# Altium schematic sheet air qulity monitor.SchDoc("air qulity monitor")
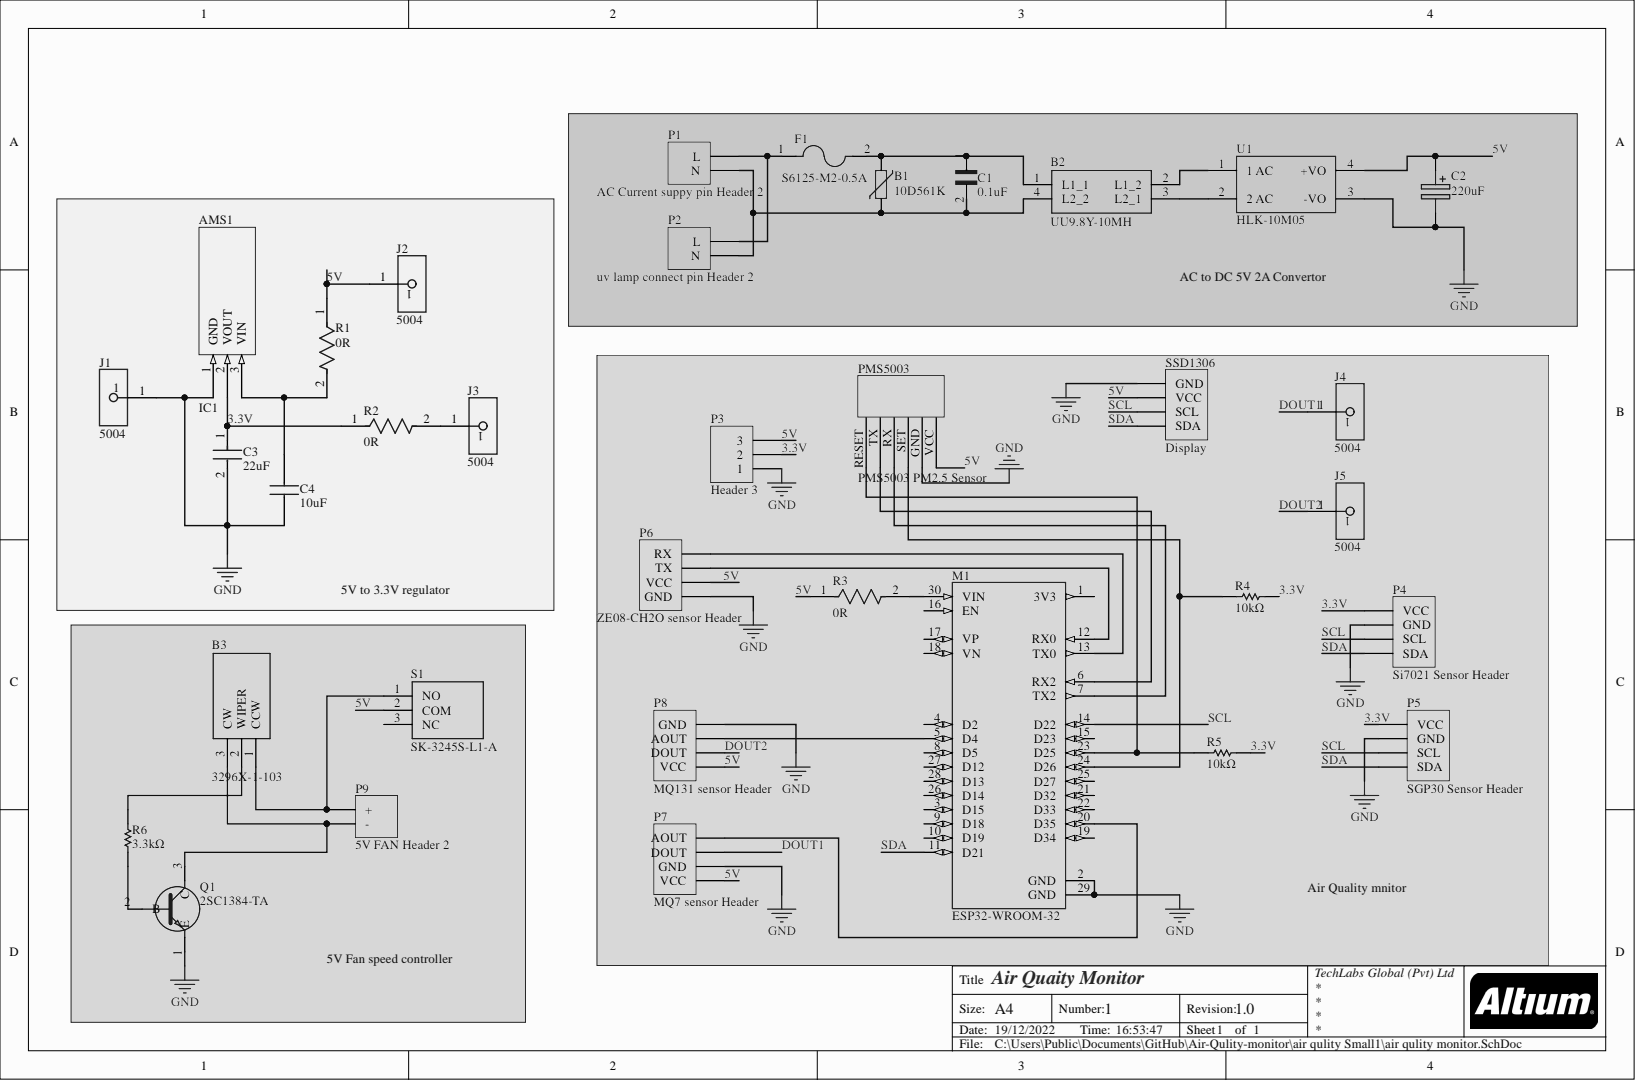
[diagram p0: air qulity monitor.SchDoc]

## Parts
AMS1  IC1  [SOT89-150P350X150-3N]
B1  10D561K  [10D561K]
B2  UU9.8Y-10MH  [UU98Y10MH]
B3  3296X-1-103  [3296X1223LF]
C1  0.1uF  [MPX-104K275VAC-C-D2]
C2  220uF  [CAPAE830X1050N]
C3  22uF  [FP-GRM219-0_2-0_15-IPC_A]
C4  10uF  [CAPC1005X70N]
F1  S6125-M2-0.5A  [CAPC6125X25N]
J1  5004  [FP-5004-MFG]
J2  5004  [FP-5004-MFG]
J3  5004  [FP-5004-MFG]
J4  5004  [FP-5004-MFG]
J5  5004  [FP-5004-MFG]
M1  ESP32-WROOM-32  [ESP32-DEVKIT-V1]
P1  AC Current suppy pin Header 2  [HDR1X2]
P2  uv lamp connect pin Header 2  [HDR1X2]
P3  Header 3  [HDR1X3]
P4  Si7021 Sensor Header  [HDR1X4]
P5  SGP30 Sensor Header  [HDR1X4]
P6  ZE08-CH2O sensor Header  Mfr.Part #: ZE08-CH2O  [HDR1X4]
P7  MQ7 sensor Header  [HDR1X4]
P8  MQ131 sensor Header  [HDR1X4]
P9  5V FAN Header 2  [HDR1X2]
PMS5003_PM2.5_Sensor  PMS5003 PM2.5 Sensor  Mfr.Part #: PMS5003  [PMS5003 PM2.5 Sensor]
Q1  2SC1384-TA  [2SC1384-TA]
R1  0R  [FP-RC0402-0_4-IPC_A]
R2  0R  [FP-RC0402-0_4-IPC_A]
R3  0R  [FP-RC0402-0_4-IPC_A]
R4  10kΩ  [RESC1005X40X25LL05T10]
R5  10kΩ  [RESC1005X40X25LL05T10]
R6  3.3kΩ  [RESC1608X55X25ML10T15]
S1  SK-3245S-L1-A  [SK3245SL1A]
SSD1306  Display  [HDR1X4]
U1  HLK-10M05  [FP-HLK-10M05-MFG]

## Nets
GND: AMS1.1 C2.2 C3.2 C4.1 J1.1 M1.2(GND) M1.29(GND) P3.1 P4.2(GND) P5.2(GND) P6.1(GND) P7.2(GND) P8.4(GND) PMS5003_PM2.5_Sensor.GND Q1.1 SSD1306.1(GND) U1.3(-VO)
3.3V: AMS1.2 C3.1 P3.2 P4.1(VCC) P5.1(VCC) R2.1 R4.2 R5.2
5V: C2.1 J2.1 P3.3 P6.2(VCC) P7.1(VCC) P8.1(VCC) PMS5003_PM2.5_Sensor.VCC R1.1 R3.1 S1.2 SSD1306.2(VCC) U1.4(+VO)
DOUT1: J4.1 P7.3
DOUT2: J5.1 P8.2
SCL: M1.14(D22) P4.3(SCL) P5.3(SCL) SSD1306.3(SCL)
SDA: M1.11(D21) P4.4(SDA) P5.4(SDA) SSD1306.4(SDA)
NetAMS1_3: AMS1.3 C4.2 R1.2
NetB1_1: B1.1 B2.1(L1_1) C1.1 F1.2
NetB1_2: B1.2 B2.4(L2_2) C1.2 P1.1 P2.1
NetB2_2: B2.2(L1_2) U1.1(1_AC)
NetB2_3: B2.3(L2_1) U1.2(2_AC)
NetB3_1: B3.1 P9.1 S1.1
NetB3_2: B3.2 R6.2
NetB3_3: B3.3 P9.2 Q1.3
NetF1_1: F1.1 P1.2 P2.2
NetJ3_1: J3.1 R2.2
NetM1_5: M1.5(D4) P8.3
NetM1_6: M1.6(RX2) PMS5003_PM2.5_Sensor.TX
NetM1_7: M1.7(TX2) PMS5003_PM2.5_Sensor.RX
NetM1_12: M1.12(RX0) P6.3(TX)
NetM1_13: M1.13(TX0) P6.4(RX)
NetM1_20: M1.20(D35) P7.4
NetM1_23: M1.23(D25) PMS5003_PM2.5_Sensor.RESET R5.1
NetM1_24: M1.24(D26) PMS5003_PM2.5_Sensor.SET R4.1
NetM1_30: M1.30(VIN) R3.2
NetQ1_2: Q1.2 R6.1
N00044:

Not connected: M1 1(3V3) 3(D15) 4(D2) 8(D5) 9(D18) 10(D19) 15(D23) 16(EN) 17(VP) 18(VN) 19(D34) 21(D32) 22(D33) 25(D27) 26(D14) 27(D12) 28(D13); S1 3

## Library data of the parts
AMS1 = IC?: 75dB@(120Hz) 1A 1.25V@(1A) ±125℃@(Tj) Fixed 3.3V~3.3V Positive 1 20V SOT-89 Linear Voltage Regulators (LDO) ROHS; Manufacturer: YONGYUTAI; Mfr. Part #: AMS1117-3.3; Component Kind: Standard; Datasheet: https://datasheet.lcsc.com/lcsc/2110121330_YONGYUTAI-AMS1117-3-3_C2904742.pdf; LCSC Part #: C2904742; Library Name: AMS1117-3.3.SchLib; Package: SOT-89
B1 = 10D561K: 920V 42.3J 180pF@1kHz 460V 350V 504V~616V 2.5kA Plugin Varistors ROHS; Manufacturer: Hongzhi Elec; Component Kind: Standard; Datasheet: https://datasheet.lcsc.com/lcsc/1811141751_Hongzhi-Elec-10D561K_C113236.pdf; LCSC Part #: C113236; Library Name: 10D561K.SchLib; Mfr. Part #: 10D561K; Package: Plugin
B2 = UU9.8Y-10MH: 10mH@1kHz 1.2Ω 2 500mA 50V Plugin Common Mode Filters ROHS; Manufacturer: FH (Guangdong Fenghua Advanced Tech); Component Kind: Standard; Datasheet: https://datasheet.lcsc.com/lcsc/1810311213_FH--Guangdong-Fenghua-Advanced-Tech-UU9-8Y-10mH_C75192.pdf; LCSC Part#: C75192; Library Name: UU9.8Y-10MH.SchLib; Mfr.Part #: UU9.8Y-10MH; Package: Plugin
B3 = 3296X-1-103: ±10% 10kΩ Plugin Variable Resistors/Potentiometers ROHS; Manufacturer: BOCHEN(Chengdu Guosheng Tech); Component Kind: Standard; Datasheet: https://datasheet.lcsc.com/lcsc/1811011704_BOCHEN-Chengdu-Guosheng-Tech-3296X-1-103_C118955.pdf; LCSC Part #: C118955; Library Name: 3296X-1-103.SchLib; Mfr. Part #: 3296X-1-103; Package: Plugin
C1 = MPX-104K275VAC-C-D2: 0.1uF ±10% -40℃~+110℃ X2 275VAC Radial Leaded,P=15mm Suppression Capacitors ROHS; Manufacturer: CORETONG; Component Kind: Standard; Datasheet: https://datasheet.lcsc.com/lcsc/2207051802_CORETONG-MPX-104K275VAC-C-D2_C3249976.pdf; LCSC Part #: C3249976; Library Name: MPX-104K275VAC-C-D2.SchLib; Mfr. Part #: MPX-104K275VAC-C-D2; Package: Radial Leaded,P=15mm; Value: 0.1uF
C2 = UUR1C221MNL1GS: -40℃~+85℃ 2000hrs@85℃ 220uF 10mm 16V 8mm 215mA@120Hz ±20% SMD,D8xL10mm Aluminum Electrolytic Capacitors - SMD ROHS; Manufacturer: Nichicon; Component Kind: Standard; Datasheet: https://datasheet.lcsc.com/lcsc/2002121532_Nichicon-UUR1C221MNL1GS_C445237.pdf; LCSC Part#: C445237; Library Name: UUR1C221MNL1GS.SchLib; Mfr.Part #: UUR1C221MNL1GS; Package: SMD,D8xL10mm; Value: 220uF
C3 = CMP-06035-056251-1: Chip Multilayer Ceramic Capacitors for General Purpose, 0805, 22uF, X5R, 15%, 20%, 16V; Manufacturer: Murata Electronics; Mfr. Part #: GRM219R61C226ME15L; Component Kind: Standard; Datasheet: https://datasheet.lcsc.com/lcsc/1811141821_Murata-Electronics-GRM219R61C226ME15L_C162295.pdf; LCSC Part #: C162295; Library Name: Altium Content Vault; Package: 0805; value: 22uF
C4 = CL05A106MQ5NUNC: 6.3V 10uF X5R ±20% 0402 Multilayer Ceramic Capacitors MLCC - SMD/SMT ROHS; Manufacturer: Samsung Electro-Mechanics; Component Kind: Standard; Datasheet Link: https://datasheet.datasheetarchive.com/originals/distributors/Datasheets-DGA10/2406160.pdf; LCSC Part #: C15525; Library Name: CL05A106MQ5NUNC.SchLib; Mfr. Part #: CL05A106MQ5NUNC; Package: 0402; value: 10uF
F1 = S6125-M2-0.5A: SMD Fuses ROHS; Manufacturer: SART(Nanjing Sart Tech); Component Kind: Standard; Datasheet: https://datasheet.lcsc.com/lcsc/2201242130_SART-Nanjing-Sart-Tech-S6125-M2-0-5A_C845172.pdf; LCSC Part #: C845172; Library Name: S6125-M2-0.5A.SchLib; Mfr. Part #: S6125-M2-0.5A; Package: SMD
J1-J5 = CMP-2000-05575-2: PC TEST POINT MINIATURE YELLOW; Manufacturer: Keystone; Component Kind: Standard; ComponentLink2Description: Datasheet; ComponentLink2URL: https://www.keyelco.com/product-pdf.cfm?p=1313; Datasheet: https://datasheet.lcsc.com/lcsc/2201121200_Keystone-5004_C2906762.pdf; LCSC Part #: C2906762; Library Name: Altium Content Vault; Mfr. Part #: 5004; Package: 5004
M1 = ESP32-DEVKIT-V1: Dual core, Wi-Fi: 2.4 GHz up to 150 Mbits/s,BLE (Bluetooth Low Energy) and legacy Bluetooth, 32 bits, Up to 240 MHz; Component Kind: Standard; Library Name: Modules.SchLib
P1 P2 P9 = Header 2: Header, 2-Pin; Component Kind: Standard; LatestRevisionDate: 17-Jul-2002; LatestRevisionNote: Re-released for DXP Platform.; Library Name: Miscellaneous Connectors.IntLib; Signal Integrity: Connector; Publisher: Altium Limited
P3 = Header 3: Header, 3-Pin; Component Kind: Standard; LatestRevisionDate: 17-Jul-2002; LatestRevisionNote: Re-released for DXP Platform.; Library Name: Miscellaneous Connectors.IntLib; Signal Integrity: Connector; Publisher: Altium Limited
P4 P5 P7 P8 SSD1306 = Header 4: Header, 4-Pin; Component Kind: Standard; Library Name: Miscellaneous Connectors.IntLib; Signal Integrity: Connector
  P5 P7 P8 SSD1306: LatestRevisionDate: 17-Jul-2002; LatestRevisionNote: Re-released for DXP Platform.; Publisher: Altium Limited
P6 = Header 4: - Sensor Modules ROHS; Manufacturer: Zhengzhou Winsen Elec Tech; Component Kind: Standard; LCSC Part#: C141344; Library Name: Miscellaneous Connectors.IntLib; Signal Integrity: Connector
PMS5003_PM2.5_Sensor = PMS5003 PM2.5 Sensor: PMS5003 Sensor Modules ROHS; Manufacturer: Beijing Plantower; Component Kind: Standard; Datasheet: https://datasheet.lcsc.com/lcsc/1810251510_Beijing-Plantower-PMS5003_C91431.pdf; LCSC Part#: C91431; Package: PMS5003; Library Name: DIY Air Quality Monitor Library.SchLib
Q1 = 2SC1384-TA: TO-92-3 Bipolar Transistors - BJT ROHS; Manufacturer: Jiangsu Changjing Electronics Technology Co., Ltd.; Component Kind: Standard; Datasheet: https://datasheet.lcsc.com/lcsc/2204131745_Jiangsu-Changjing-Electronics-Technology-Co---Ltd--2SC1384-TA_C2910422.pdf; LCSC Part #: C2910422; Library Name: 2SC1384-TA.SchLib; Mfr. Part #: 2SC1384-TA; Package: TO-92-3
R1-R3 = CMP-2002-07342-2: RES SMD 0 OHM JUMPER 1/16W 0402; Manufacturer: Yageo; Mfr. Part #: RC0402FR-070RL; Component Kind: Standard; Datasheet: https://www.lcsc.com/product-detail/Chip-Resistor-Surface-Mount_YAGEO-RC0402FR-070RL_C106231.html; LCSC Part #: C106231; Library Name: Altium Content Vault; Package: 0402; value: 0R
R4 R5 = CMP-2002-07353-1: 62.5mW 50V ±100ppm/℃ ±1% -55℃~+155℃ 10kΩ 0402 Chip Resistor - Surface Mount ROHS; Manufacturer: Yageo / Phycomp; Mfr. Part #: RC0402FR-0710KL; Component Kind: Standard; Datasheet: https://datasheet.lcsc.com/lcsc/1809301715_YAGEO-RC0402FR-0710KL_C60490.pdf; LCSC Part #: C60490; Library Name: Altium Content Vault; Package: 0402; value: 10kΩ
R6 = CMP-1012-00567-2: 100mW Thick Film Resistors 75V ±100ppm/℃ ±1% -55℃~+155℃ 3.3kΩ 0603 Chip Resistor - Surface Mount ROHS; Manufacturer: YAGEO; Mfr. Part #: RC0603FR-073K3L; Component Kind: Standard; Datasheet: https://datasheet.lcsc.com/lcsc/1811021410_YAGEO-RC0603FR-073K3L_C108078.pdf; LCSC Part #: C108078; Library Name: Altium Content Vault; Package: 0603; Resistance: 3.3kΩ
S1 = SK-3245S-L1-A: Surface Mount 50mA DPDT 3.2mm 12V 9.1mm 12V 3.55mm 50mA 10000 SMDSplicing Rectangular columnar -40℃~+85℃ Black SMD Slide Switches ROHS; Manufacturer: XKB Connectivity; Component Kind: Standard; Datasheet: https://datasheet.lcsc.com/lcsc/2002271841_XKB-Connectivity-SK-3245S-L1-A_C405951.pdf; LCSC Part#: C405951; Library Name: SK-3245S-L1-A.SchLib; Mfr.Part #: SK-3245S-L1-A; Package: SMD
U1 = CMP-218581-000001-1: 5V 2A 90VAC~265VAC 1 120V~350V Isolated Regulated 10W AC-DC 80% Plugin Power Modules ROHS; Manufacturer: HI-LINK; Component Kind: Standard; LCSC Part#: C403745; Library Name: Altium Content Vault; Mfr.Part #: HLK-10M05; Package: Plugin
